# Altium schematic sheet ADC.SCHDOC(ADC)
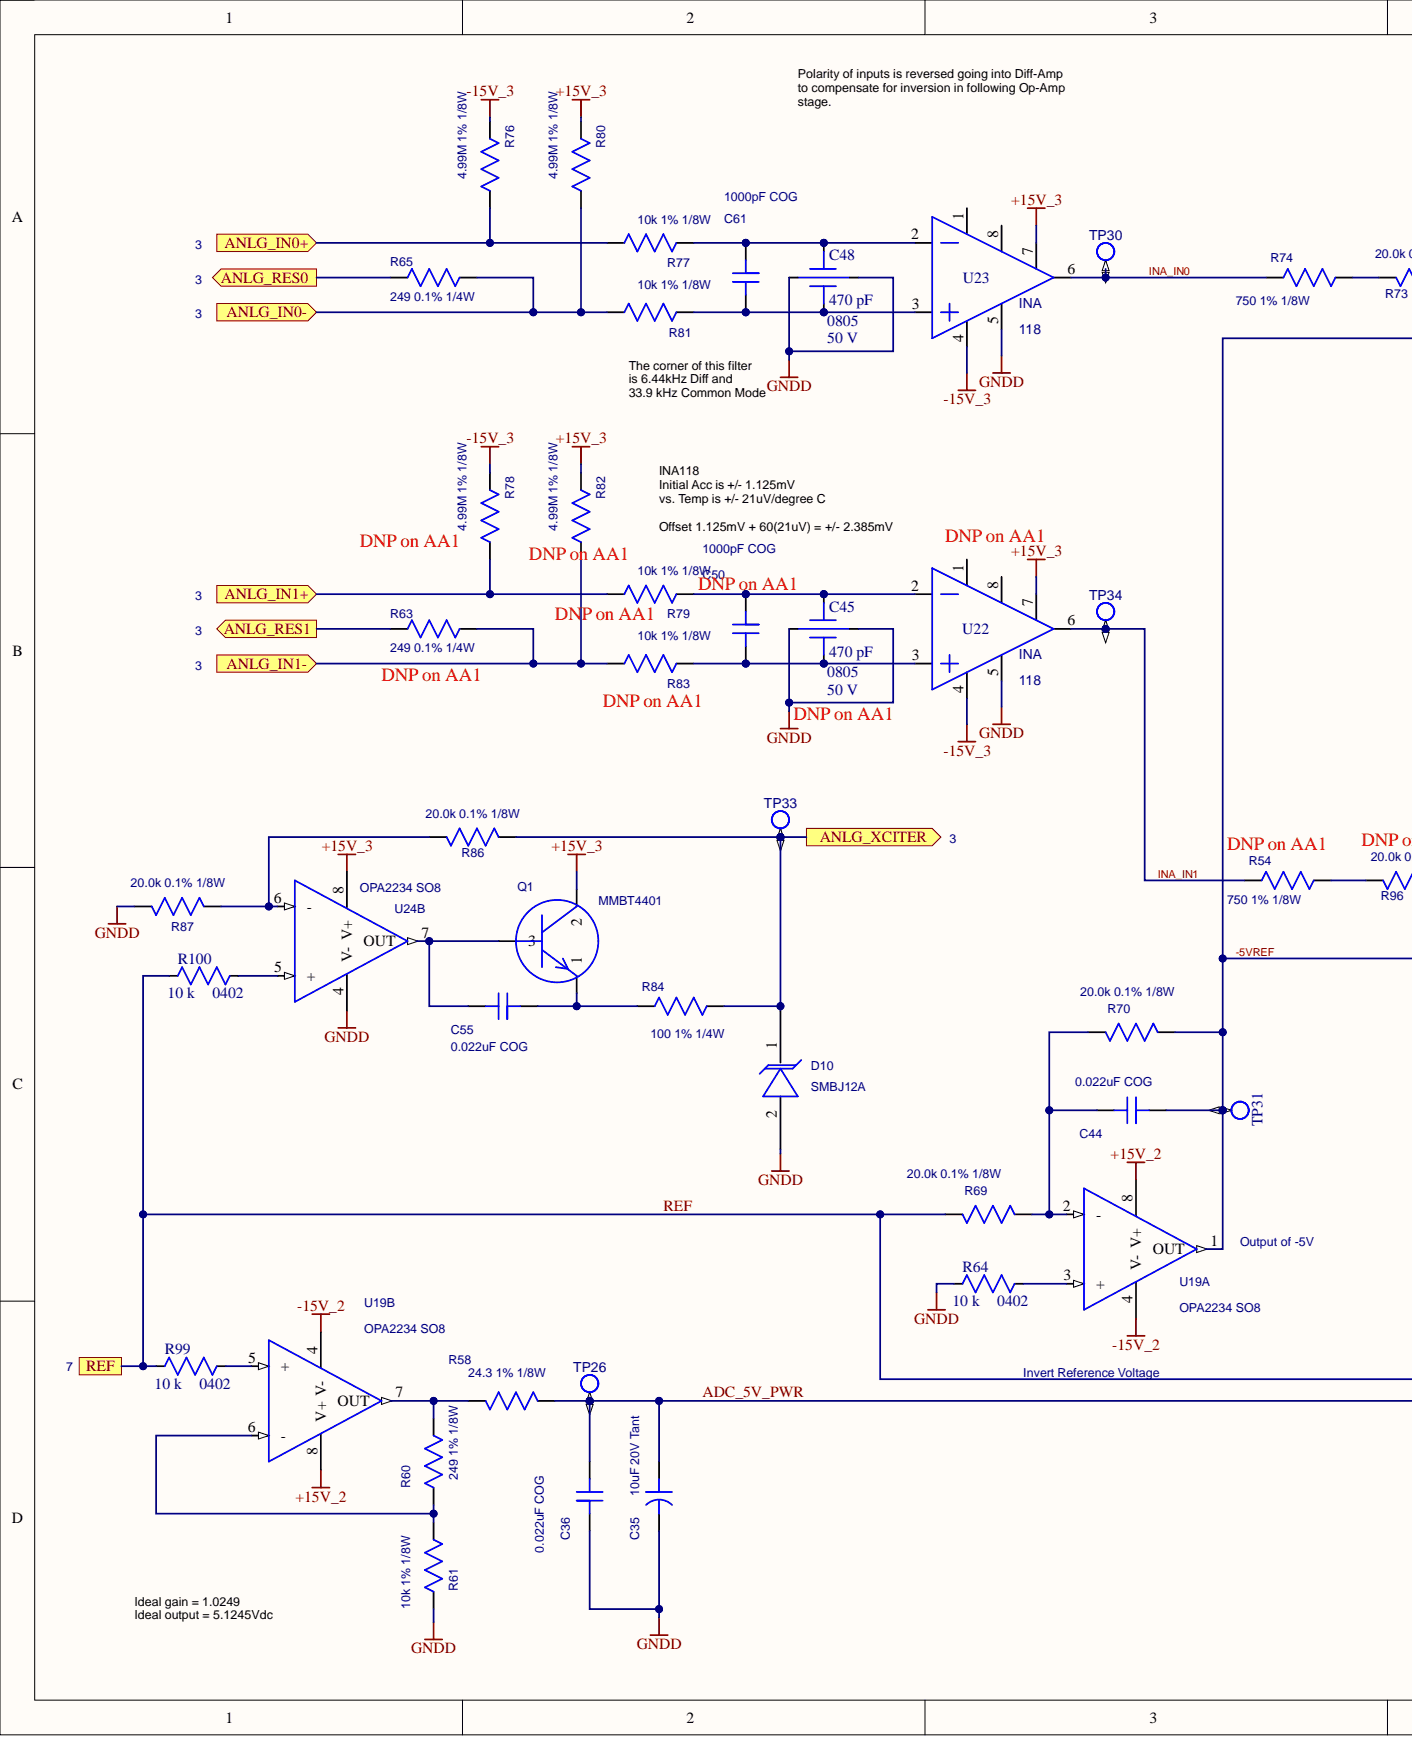
[diagram p0: ADC.SCHDOC, left]
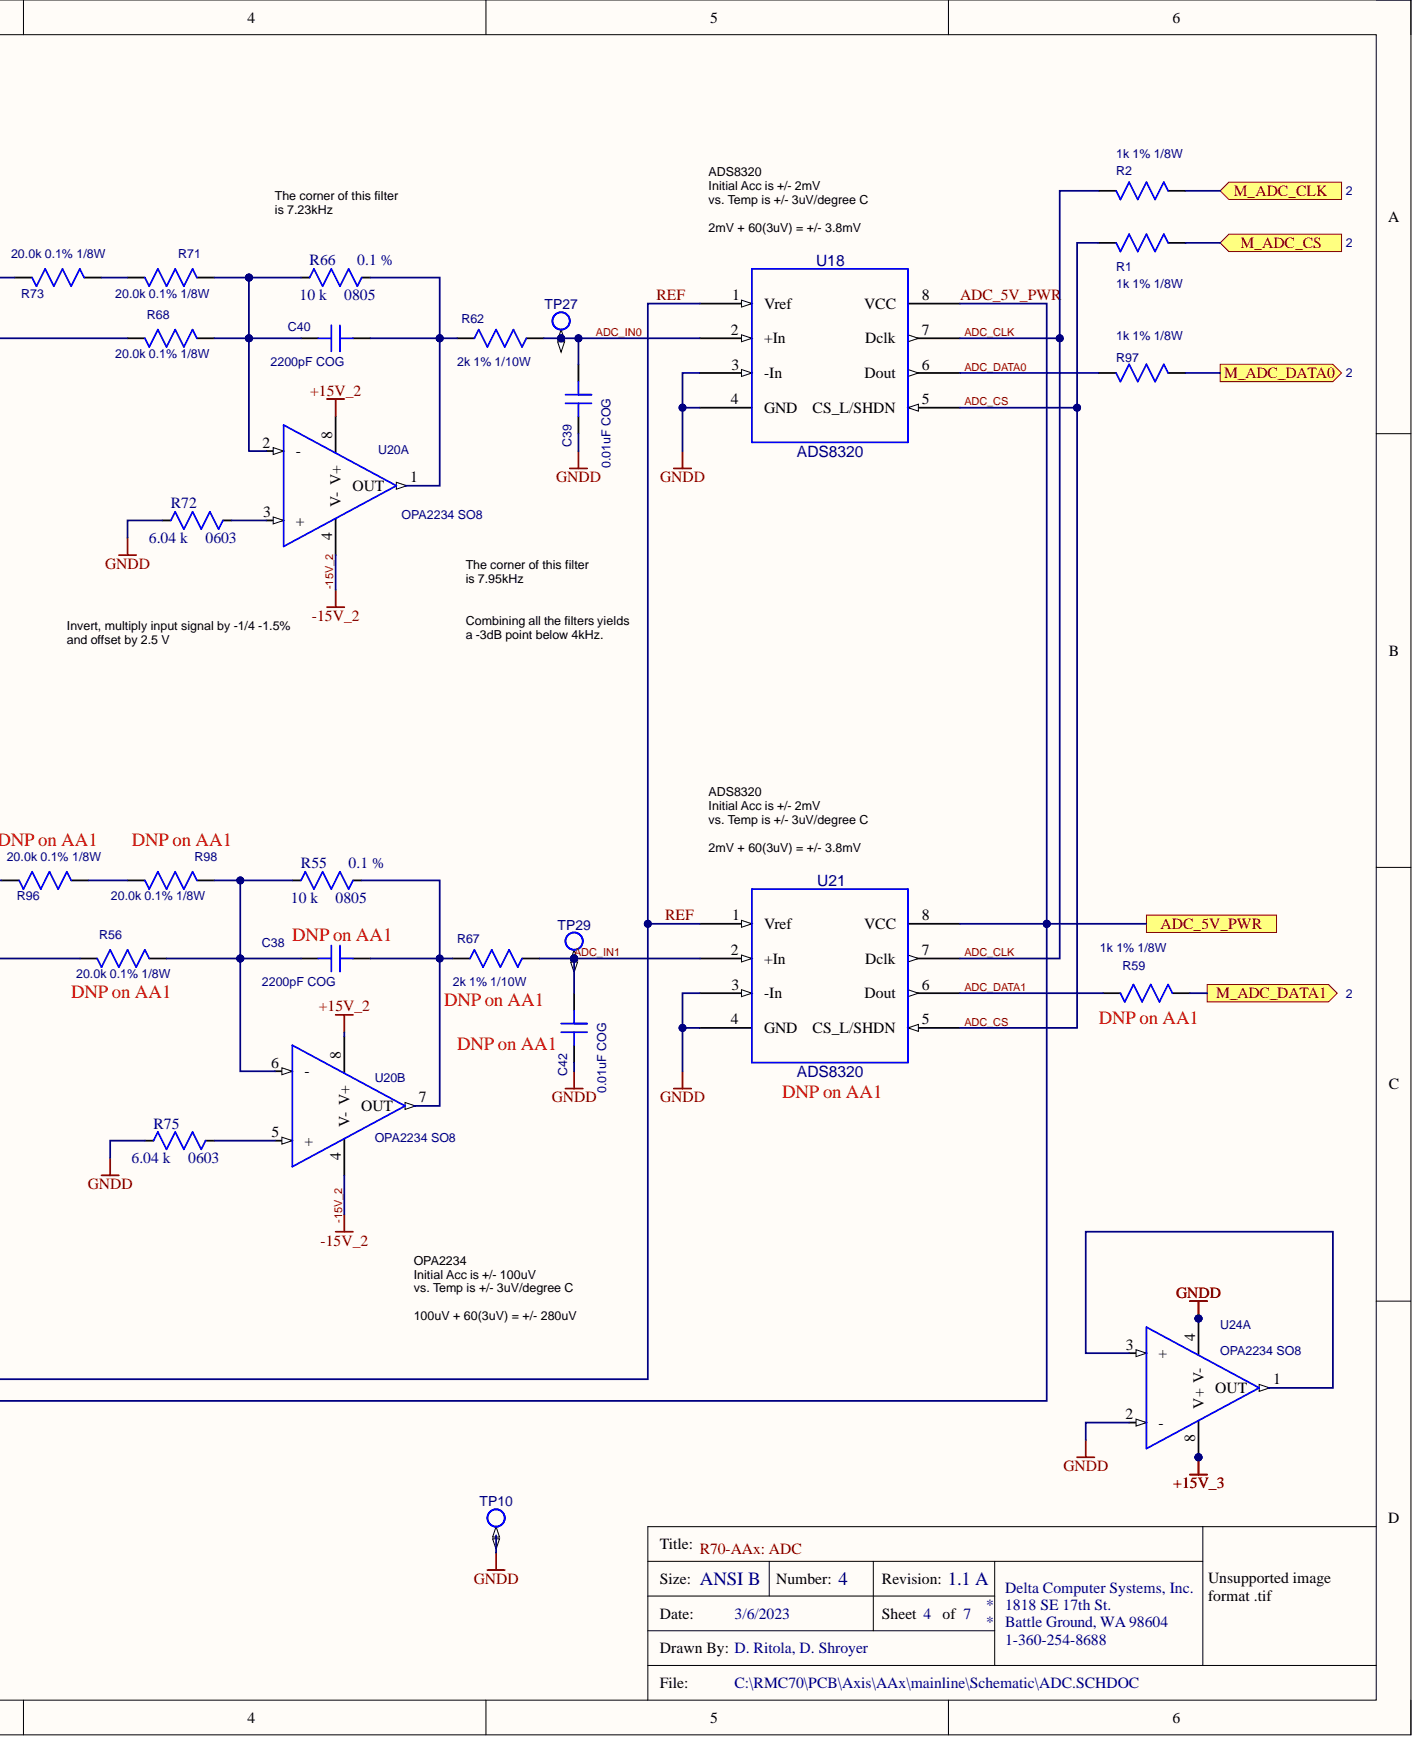
[diagram p1: ADC.SCHDOC, right]

## Parts
C35  10uF 20V Tant  [CAP_SMT1210]
C36  0.022uF COG  [CC0805]
C38  2200pF COG  [CC0805]
C39  0.01uF COG  [CC0805]
C40  2200pF COG  [CC0805]
C42  0.01uF COG  [CC0805]
C44  0.022uF COG  [CC0805]
C45  470 pF  CaseSize: 0805  RatedVoltage: 50 V  [CAP X2Y [0805]]
C48  470 pF  CaseSize: 0805  RatedVoltage: 50 V  [CAP X2Y [0805]]
C50  1000pF COG  [CC0805]
C55  0.022uF COG  [CC0805]
C61  1000pF COG  [CC0805]
D10  SMBJ12A  [TRANZORBSMT]
Q1  MMBT4401  [SOT23]
R1  1k 1% 1/8W  [CC0805]
R2  1k 1% 1/8W  [CC0805]
R54  750 1% 1/8W  [CC0805]
R55  10 k  CaseSize: 0805  Tolerance: 0.1 %  [RESC2012-N [0805]]
R56  20.0k 0.1% 1/8W  [CC0805]
R58  24.3 1% 1/8W  [CC0805]
R59  1k 1% 1/8W  [CC0805]
R60  249 1% 1/8W  [CC0805]
R61  10k 1% 1/8W  [CC0805]
R62  2k 1% 1/10W  [CC0603]
R63  249 0.1% 1/4W  [CC1210]
R64  10 k  CaseSize: 0402  [RESC1005-N [0402]]
R65  249 0.1% 1/4W  [CC1210]
R66  10 k  CaseSize: 0805  Tolerance: 0.1 %  [RESC2012-N [0805]]
R67  2k 1% 1/10W  [CC0603]
R68  20.0k 0.1% 1/8W  [CC0805]
R69  20.0k 0.1% 1/8W  [CC0805]
R70  20.0k 0.1% 1/8W  [CC0805]
R71  20.0k 0.1% 1/8W  [CC0805]
R72  6.04 k  CaseSize: 0603  [RESC1608-N [0603]]
R73  20.0k 0.1% 1/8W  [CC0805]
R74  750 1% 1/8W  [CC0805]
R75  6.04 k  CaseSize: 0603  [RESC1608-N [0603]]
R76  4.99M 1% 1/8W  [CC0805]
R77  10k 1% 1/8W  [CC0805]
R78  4.99M 1% 1/8W  [CC0805]
R79  10k 1% 1/8W  [CC0805]
R80  4.99M 1% 1/8W  [CC0805]
R81  10k 1% 1/8W  [CC0805]
R82  4.99M 1% 1/8W  [CC0805]
R83  10k 1% 1/8W  [CC0805]
R84  100 1% 1/4W  [1206]
R86  20.0k 0.1% 1/8W  [CC0805]
R87  20.0k 0.1% 1/8W  [CC0805]
R96  20.0k 0.1% 1/8W  [CC0805]
R97  1k 1% 1/8W  [CC0805]
R98  20.0k 0.1% 1/8W  [CC0805]
R99  10 k  CaseSize: 0402  [RESC1005-N [0402]]
R100  10 k  CaseSize: 0402  [RESC1005-N [0402]]
TP10  TP1  [TESTP_XY60D40]  (no BOM)
TP26  TP1  [TESTP_XY60D40]  (value not drawn)  (no BOM)
TP27  TP1  [TESTP_XY60D40]  (value not drawn)  (no BOM)
TP29  TP1  [TESTP_XY60D40]  (value not drawn)  (no BOM)
TP30  TP1  [TESTP_XY60D40]  (value not drawn)  (no BOM)
TP31  TP1  [TESTP_XY60D40]  (value not drawn)  (no BOM)
TP33  TP1  [TESTP_XY60D40]  (value not drawn)  (no BOM)
TP34  TP1  [TESTP_XY60D40]  (value not drawn)  (no BOM)
U18  ADS8320  [MSOP8]
U19  OPA2234 SO8  [SO8]  (parts A,B)
U20  OPA2234 SO8  [SO8]  (parts A,B)
U21  ADS8320  [MSOP8]
U22  INA118P SO8  [SO8]  (value not drawn)
U23  INA118P SO8  [SO8]  (value not drawn)
U24  OPA2234 SO8  [SO8]  (parts A,B)

## Ports
ADC_5V_PWR, ANLG_IN0+, ANLG_IN0-, ANLG_IN1+, ANLG_IN1-, ANLG_RES0, ANLG_RES1, ANLG_XCITER, M_ADC_CLK, M_ADC_CS, M_ADC_DATA0, M_ADC_DATA1, REF

## Nets
+15V_2: U19.8(V+) U20.8(V+)
+15V_3: Q1.3 R80.1 R82.1 U22.7 U23.7 U24.8(V+)
-15V_3: R76.1 R78.1 U22.4 U23.4
GNDD: C35.2 C36.2 C39.1 C42.1 C45.3 C45.4 C48.3 C48.4 D10.2 R61.2 R64.1 R72.1 R75.1 R87.1 TP10.1 U18.3(-In) U18.4(GND) U21.3(-In) U21.4(GND) U22.5 U23.5 U24.2(-) U24.4(V-)
-5VREF: C44.2 R56.1 R68.1 R70.2 TP31.1 U19.1(OUT)
-15V_2: U19.4(V-) U20.4(V-)
ADC_5V_PWR: C35.1 C36.1 R58.2 TP26.1 U18.8(VCC) U21.8(VCC) ; port ADC_5V_PWR
ADC_CLK: R2.1 U18.7(Dclk) U21.7(Dclk)
ADC_CS: R1.1 U18.5(CS_L/SHDN) U21.5(CS_L/SHDN)
ADC_DATA0: R97.1 U18.6(Dout)
ADC_DATA1: R59.1 U21.6(Dout)
ADC_IN0: C39.2 R62.2 TP27.1 U18.2(+In)
ADC_IN1: C42.2 R67.2 TP29.1 U21.2(+In)
ANLG_IN0+: R76.2 R77.2 ; port ANLG_IN0+
ANLG_IN0-: R65.2 R80.2 R81.2 ; port ANLG_IN0-
ANLG_IN1+: R78.2 R79.2 ; port ANLG_IN1+
ANLG_IN1-: R63.2 R82.2 R83.2 ; port ANLG_IN1-
ANLG_RES0: R65.1 ; port ANLG_RES0
ANLG_RES1: R63.1 ; port ANLG_RES1
ANLG_XCITER: D10.1 R84.2 R86.2 TP33.1 ; port ANLG_XCITER
INA_IN0: R74.1 TP30.1 U23.6
INA_IN1: R54.1 TP34.1 U22.6
M_ADC_CLK: R2.2 ; port M_ADC_CLK
M_ADC_CS: R1.2 ; port M_ADC_CS
M_ADC_DATA0: R97.2 ; port M_ADC_DATA0
M_ADC_DATA1: R59.2 ; port M_ADC_DATA1
REF: R69.1 R99.1 R100.1 U18.1(Vref) U21.1(Vref) ; port REF
NetC38_1: C38.1 R55.1 R56.2 R98.2 U20.6(-)
NetC38_2: C38.2 R55.2 R67.1 U20.7(OUT)
NetC40_1: C40.1 R66.1 R68.2 R71.2 U20.2(-)
NetC40_2: C40.2 R62.1 R66.2 U20.1(OUT)
NetC44_1: C44.1 R69.2 R70.1 U19.2(-)
NetC45_1: C45.1 C50.2 R79.1 U22.2
NetC45_2: C45.2 C50.1 R83.1 U22.3
NetC48_1: C48.1 C61.2 R77.1 U23.2
NetC48_2: C48.2 C61.1 R81.1 U23.3
NetC55_1: C55.1 Q1.2 U24.7
NetC55_2: C55.2 Q1.1 R84.1
NetR54_2: R54.2 R96.1
NetR58_1: R58.1 R60.1 U19.7
NetR60_2: R60.2 R61.1 U19.6(-)
NetR64_2: R64.2 U19.3(+)
NetR71_1: R71.1 R73.2
NetR72_2: R72.2 U20.3(+)
NetR73_1: R73.1 R74.2
NetR75_2: R75.2 U20.5(+)
NetR86_1: R86.1 R87.2 U24.6(-)
NetR96_2: R96.2 R98.1
NetR99_2: R99.2 U19.5(+)
NetR100_2: R100.2 U24.5(+)
NetU24_1: U24.1(OUT) U24.3(+)

Not connected: U22 1 8; U23 1 8

## Library data of the parts
C35 = CAP_SMT1210: Cap Tant 10uF 20V 10% B; Manufacturer: AVX; PartNumber: TAJB106K020RNJ; Component Kind: Standard; Value: 10uF 20V Tant
C36 C44 C55 = CAPNP_SMT0805: Cap Cer 0.022uF 50V 5% 0805 C0G; Manufacturer: Venkel; PartNumber: C0805C0G500-223JNE; Component Kind: Standard; Value: 0.022uF COG
C38 C40 = CAPNP_SMT0805: Cap Cer 2200pF 50V 5% 0805 C0G; Manufacturer: Venkel; PartNumber: C0805C0G500-222JNE; Component Kind: Standard; Value: 2200pF COG
C39 C42 = CAPNP_SMT0805: Cap Cer 0.01uF 50V 5% 0805 C0G; Manufacturer: Kemet; PartNumber: C0805C103J5GACTU; Component Kind: Standard; Value: 0.01uF COG
C45 C48 = Capacitor - X2Y: Cap Cer 470pF 50V 20% 0805 C0G X2Y; Manufacturer: Johanson Dielectric; ManufacturerAlt1: Yageo; PartNumber: 500X15N471MV4E; Type: Ceramic X2Y C0G; Component Kind: Standard; ComponentType: Capacitor; DateUpdated: 02/06/2023; Library Name: Capacitor.IntLib; Tolerance: 20%; Value: 470 pF
C50 C61 = CAPNP_SMT0805: Cap Cer 1000pF 50V 5% 0805 C0G; Manufacturer: Kemet; PartNumber: C0805C102J5GACTU; Component Kind: Standard; Value: 1000pF COG
D10 = TVS UNIPOLAR SMT_VARIANT_1: TVS Diode 12VWM 19.9VC SMB; Manufacturer: Fairchild; Component Kind: Standard; PartNumber: SMBJ12A; Value: SMBJ12A
Q1 = MMBT4401: Transistor NPN 40V 250MHz SOT23; Manufacturer: ON Semi; PartNumber: MMBT4401LT1G; Component Kind: Standard
R1 = RES_GEN_SMT0805: Res 1k 1% 1/8W 0805; Manufacturer: Venkel; PartNumber: CR0805-8W-1001FT; Component Kind: Standard; Value: 1k 1% 1/8W
R2 R59 R97 = RES_GEN_SMT0805_VARIANT_2: Res 1k 1% 1/8W 0805; Manufacturer: Venkel; PartNumber: CR0805-8W-1001FT; Component Kind: Standard; Value: 1k 1% 1/8W
R54 R74 = RES_GEN_SMT0805: Res 750 1% 1/8W 0805; Manufacturer: Venkel; PartNumber: CR0805-8W-7500FT; Component Kind: Standard; Value: 750 1% 1/8W
R55 R66 = Resistor: Res 10k 0.1% 1/8W 0805; Manufacturer: Venkel; ManufacturerAlt1: Various; PartNumber: TFCR0805-8W-E-1002BT; Notes: ERA0030; Component Kind: Standard; ComponentType: Resistor; DateUpdated: 01/31/2023; Library Name: Resistor.IntLib; PartNumberAlt1: Various; PowerRating: 1/8 W; Value: 10 k
R56 R68 R71 R73 R86 R87 R96 R98 = RES_GEN_SMT0805: Res 20k 0.1% 1/8W 0805; Manufacturer: Panasonic; PartNumber: ERA-6AEB203V; Component Kind: Standard; Value: 20.0k 0.1% 1/8W
R58 = RES_GEN_SMT0805_VARIANT_2: Res 24.3 1% 1/8W 0805; Manufacturer: Venkel; PartNumber: CR0805-8W-24R3FT; Component Kind: Standard; Value: 24.3 1% 1/8W
R60 = RES_GEN_SMT0805: Res 249 1% 1/8W 0805; Manufacturer: Venkel; PartNumber: CR0805-8W-2490FT; Component Kind: Standard; Value: 249 1% 1/8W
R61 R77 R79 R81 R83 = RES_GEN_SMT0805: Res 10k 1% 1/8W 0805; Manufacturer: Venkel; PartNumber: CR0805-8W-1002FT; Component Kind: Standard; Value: 10k 1% 1/8W
R62 R67 = RES_GEN_SMT0603: Res 2k 1% 1/10W 0603; Manufacturer: Venkel; PartNumber: CR0603-10W-2001FT; Component Kind: Standard; Value: 2k 1% 1/10W
R63 R65 = RES_GEN_SMT1210: Res 249 0.1% 1/4W 1210 25ppm; Manufacturer: Venkel; PartNumber: TFCR1210-4W-E-2490BT; Component Kind: Standard; Value: 249 0.1% 1/4W
R64 R99 R100 = Resistor: Res 10k 1% 1/16W 0402; Manufacturer: Venkel; ManufacturerAlt1: Various; PartNumber: CR0402-16W-1002FT; Notes: ERA0095; Tolerance: 1.0%; Component Kind: Standard; ComponentType: Resistor; DateUpdated: 01/31/2023; Library Name: Resistor.IntLib; PartNumberAlt1: Various; PowerRating: 1/16 W; Value: 10 k
R69 R70 = RES_GEN_SMT0805_VARIANT_2: Res 20k 0.1% 1/8W 0805; Manufacturer: Panasonic; PartNumber: ERA-6AEB203V; Component Kind: Standard; Value: 20.0k 0.1% 1/8W
R72 R75 = Resistor: Res 6.04k 1% 1/10W 0603; Manufacturer: Venkel; ManufacturerAlt1: Various; PartNumber: CR0603-10W-6041FT; Notes: ERA0132; Tolerance: 1.0%; Component Kind: Standard; ComponentType: Resistor; DateUpdated: 01/31/2023; Library Name: Resistor.IntLib; PartNumberAlt1: Various; PowerRating: 1/10 W; Value: 6.04 k
R76 R78 R80 R82 = RES_GEN_SMT0805: Res 4.99M 1% 1/8W 0805; Manufacturer: Venkel; PartNumber: CR0805-8W-4994FT; Component Kind: Standard; Value: 4.99M 1% 1/8W
R84 = RES_GEN_1206_VARIANT_1: Res 100 1% 1/4W 1206; Manufacturer: Venkel; PartNumber: CR1206-4W-1000FT; Component Kind: Standard; Value: 100 1% 1/4W
TP10 TP26 TP27 TP29-TP31 TP33 TP34 = TP1: PDIF_I_PID: TP0; PDIF_TY: 255; Description: TP1
U18 U21 = ADS8320EB: ADC 16bit 1-Ch SRL 8TSSOP; Manufacturer: TI; PartNumber: ADS8320EB/2K5; Component Kind: Standard; Value: ADS8320
U19 U20 U24 = OPA2234 SO8: OpAmp GP 350kHz 8SOIC; Manufacturer: TI; PartNumber: OPA2234UA/2K5; Component Kind: Standard
U22 U23 = INA118P SO8: OpAmp Instr 800kHz 8SOIC; Manufacturer: TI; PartNumber: INA118U; PDIF_I_PID: 1na114; PDIF_TY: 255; Component Kind: Standard
